# Altium schematic sheet RED_CIRCUITRY_1.SchDoc(RED_CIRCUITRY_1)
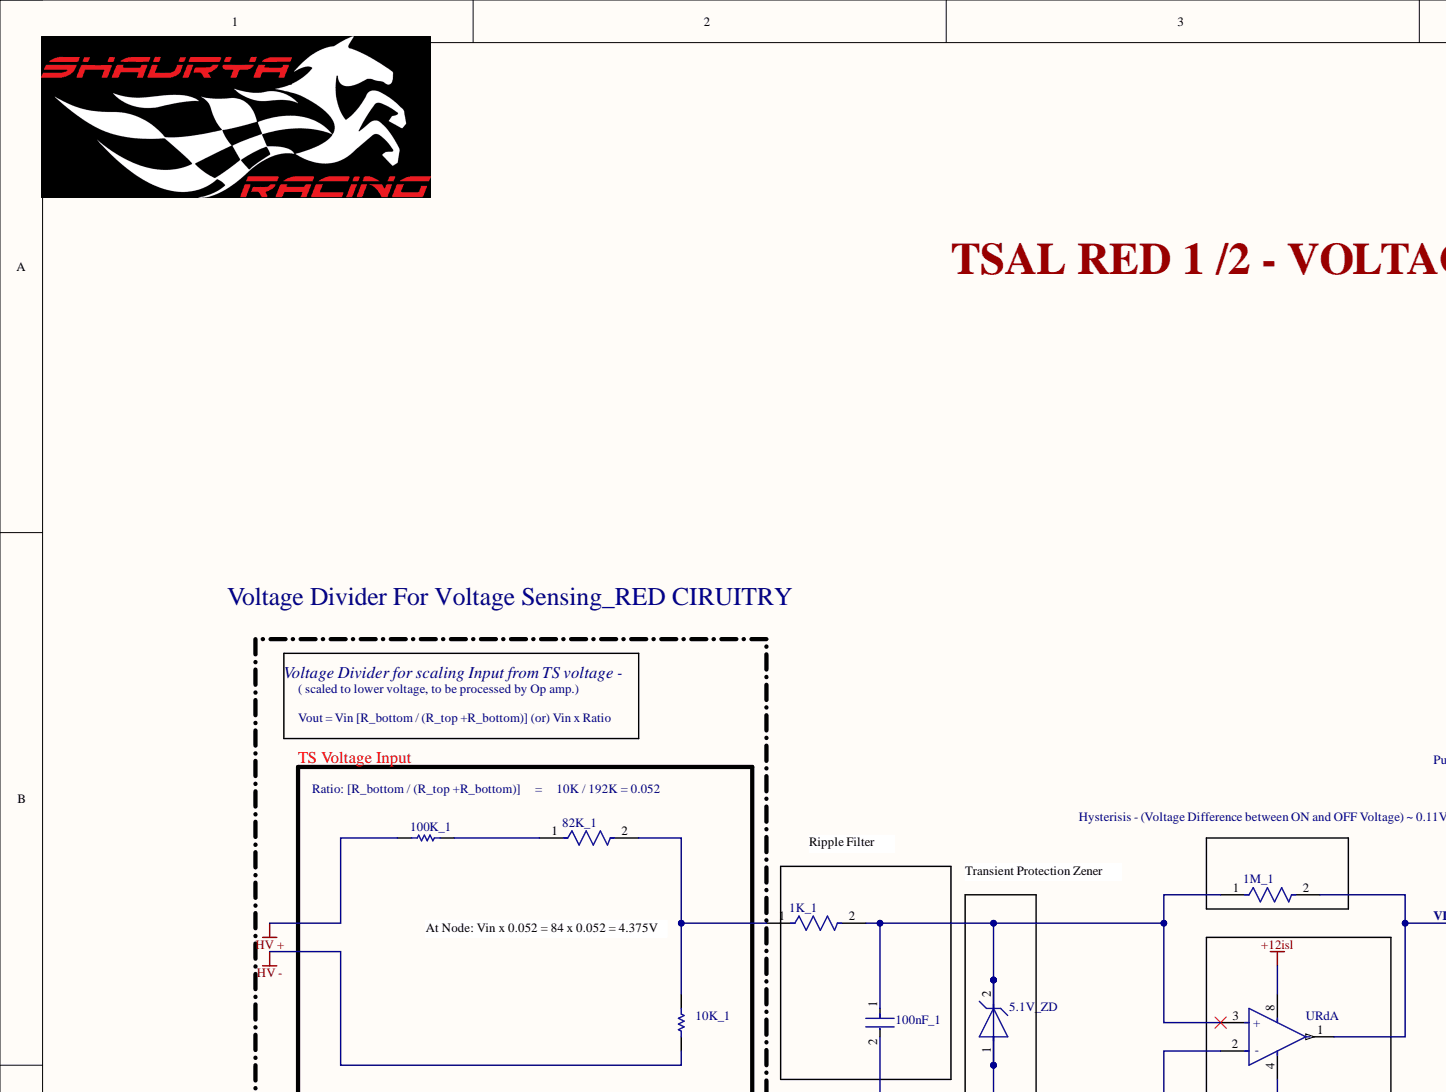
[diagram p0: RED_CIRCUITRY_1.SchDoc, top left]
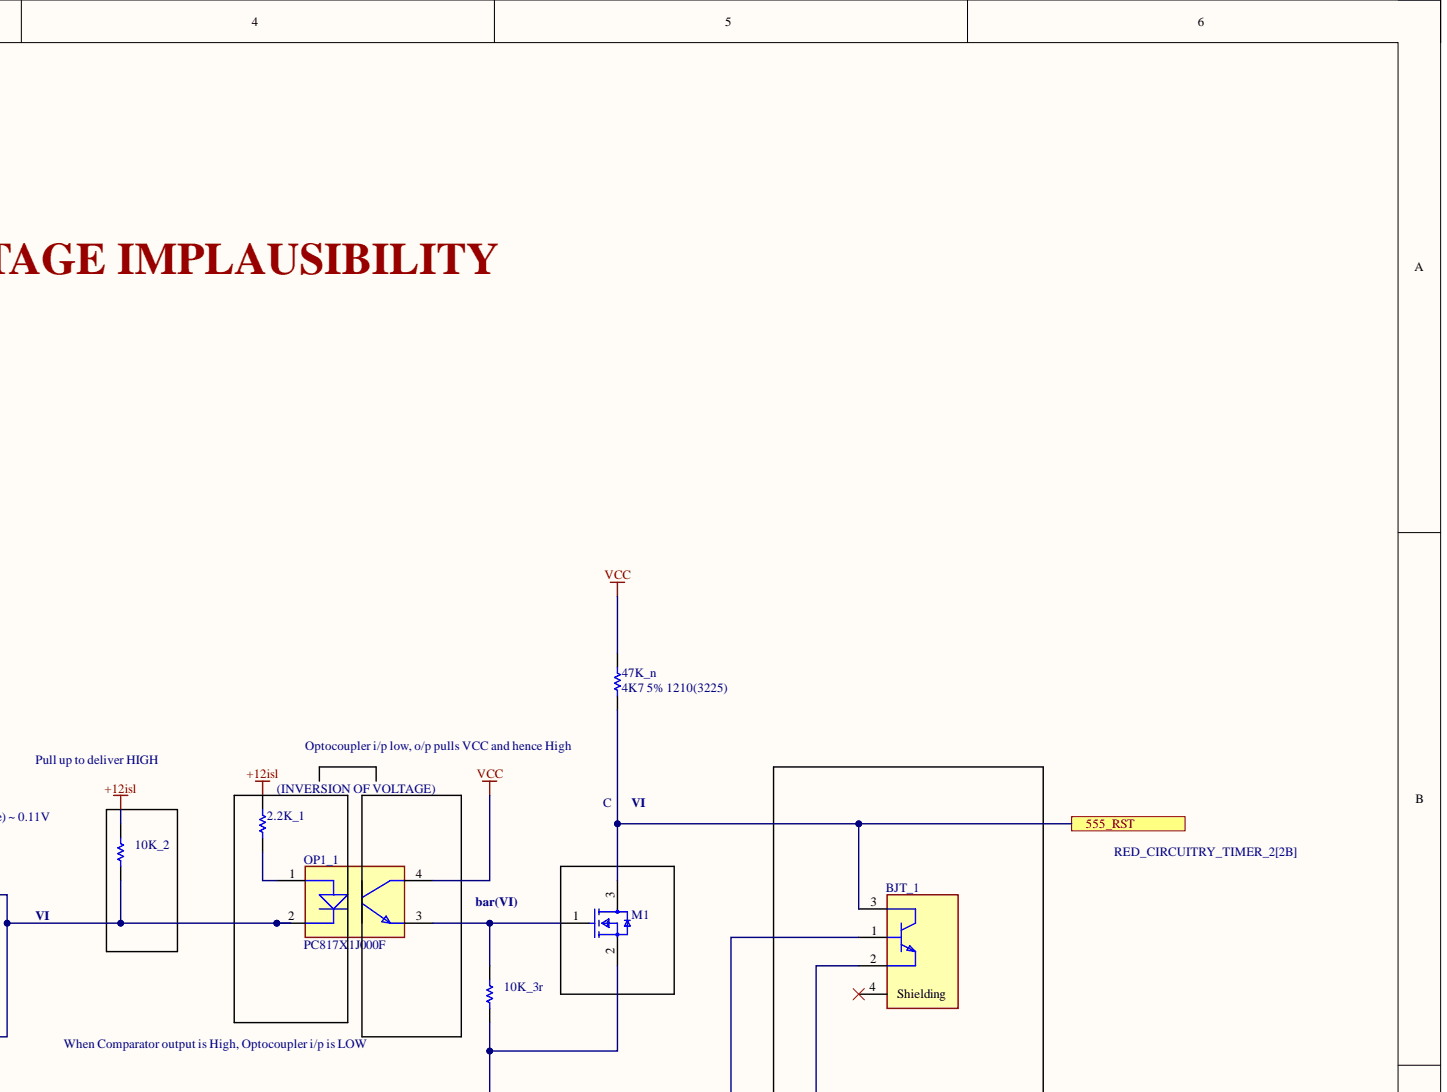
[diagram p1: RED_CIRCUITRY_1.SchDoc, top right]
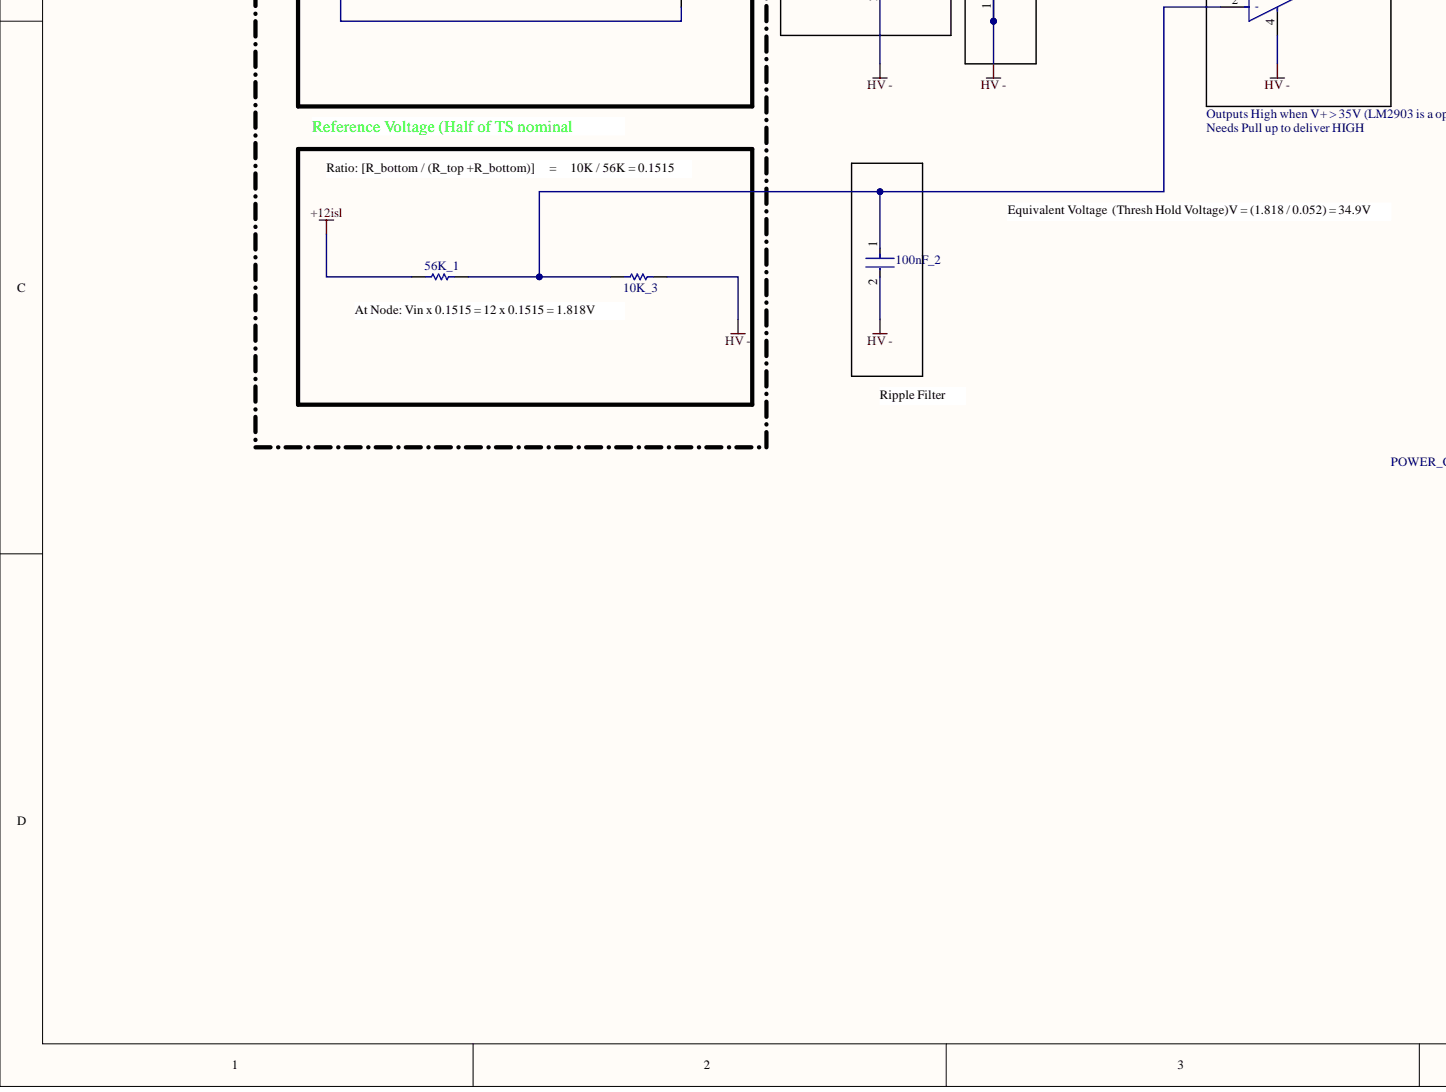
[diagram p2: RED_CIRCUITRY_1.SchDoc, bottom left]
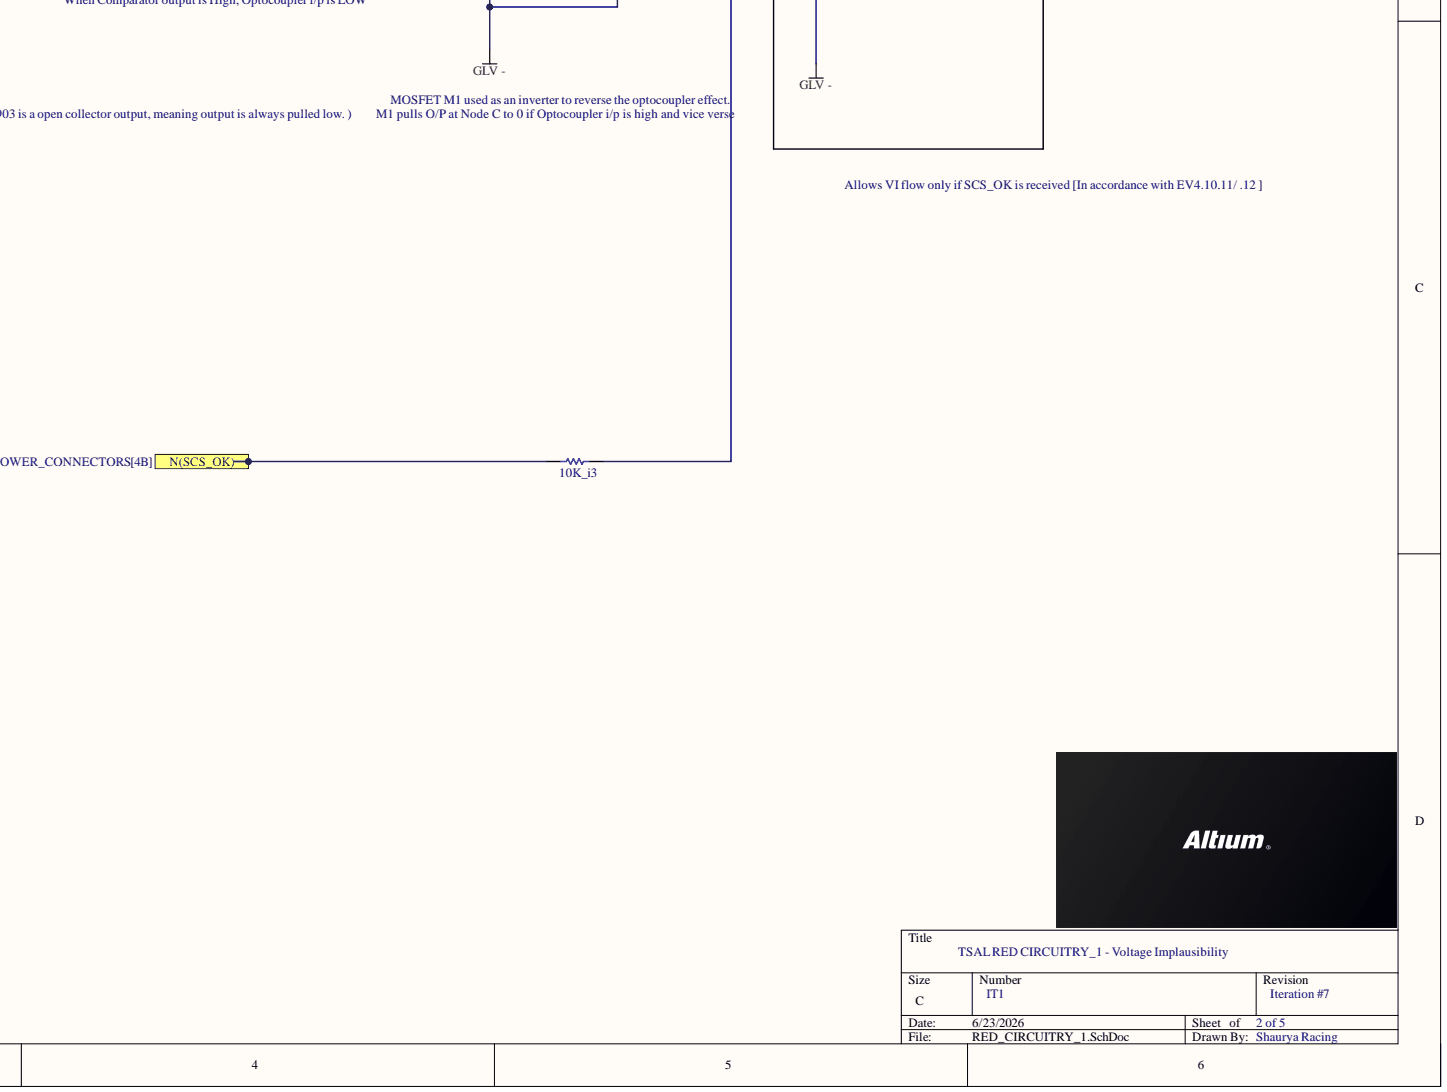
[diagram p3: RED_CIRCUITRY_1.SchDoc, bottom right]

## Parts
1K_1  CRCW08051K00JNEC  (value not drawn)
1M_1  MCU08050D1004BP500  (value not drawn)
2.2K_1  2K2 5% 0402(1005)  (value not drawn)
5.1V_ZD  BZD27C5V1P-E3-08  (value not drawn)
10K_1  TNPW121010K0BEEN  (value not drawn)
10K_2  TNPW121010K0BEEN  (value not drawn)
10K_3  TNPW121010K0BEEN  (value not drawn)
10K_3r  TNPW121010K0BEEN  (value not drawn)
10K_i3  TNPW121010K0BEEN  (value not drawn)
47K_n  4K7 5% 1210(3225)
56K_1  56K 5% 0805(2012)  (value not drawn)
82K_1  CRCW121082K0FKEA  (value not drawn)
100K_1  TNPW0805100KDEEA  (value not drawn)
100nF_1  C0805C104K5RACTU  (value not drawn)
100nF_2  C0805C104K5RACTU  (value not drawn)
BJT_1  JANTX2N2222AUB/TR  (value not drawn)
M1  2N7002H6327XTSA2  (value not drawn)
OP1_1  PC817X1J000F
URd  LM2903BIDR  (value not drawn)  (part A)

## Ports
555_RST, N(SCS_OK)

## Nets
+12isl: 2.2K_1.2 10K_2.2 56K_1.1 URd.8
GLV -: 10K_3r.1 BJT_1.2 M1.2
HV +: 100K_1.1
HV -: 1K_1.2 1M_1.1 5.1V_ZD.1 5.1V_ZD.2 10K_1.1 10K_3.2 100nF_1.1 100nF_1.2 100nF_2.2 URd.3(+) URd.4
VCC: 47K_n.2 OP1_1.4
555_RST: 47K_n.1 BJT_1.3 M1.3 ; port 555_RST
N(SCS_OK): 10K_i3.2 ; port N(SCS_OK)
Net1K_1_1: 1K_1.1 10K_1.2 82K_1.2
Net1M_1_2: 1M_1.2 10K_2.1 OP1_1.2 URd.1
Net2.2K_1_1: 2.2K_1.1 OP1_1.1
Net10K_3_1: 10K_3.1 56K_1.2 100nF_2.1 URd.2(-)
Net10K_3r_2: 10K_3r.2 M1.1 OP1_1.3
Net10K_i3_1: 10K_i3.1 BJT_1.1
Net82K_1_1: 82K_1.1 100K_1.2

Not connected: BJT_1 4(Shielding)

## Library data of the parts
1K_1 = CMP-02407-005778-1: RES Thick Film, 1kΩ, 5%, 0.125W, 200ppm/°C, 0805; Max Power Dissipation: 125mW; Resistance: 1kR; Case/Package: 0805; Component Kind: Standard; Composition: Thick Film; Contact Plating: Tin; Features: Automotive AEC-Q200; Height: 0.02inch; Lead Free: Lead Free; Length: 0.079inch; Library Name: Altium Content Vault; Max Operating Temperature: 155°C; Min Operating Temperature: -55°C; Number of Terminations: 2; Package Quantity: 20000; Packaging: Tape and Reel; Radiation Hardening: No; Temperature Coefficient: 200ppm/°C; Tolerance: 5%; Width: 0.049inch
1M_1 = CMP-02407-001361-1: RES 1.05K OHM 0.5% 1/5W 0805; Resistance: 1MR; Tolerance: 0.1%; Case/Package: 0805; Component Kind: Standard; Height: 450um; Lead Free: Lead Free; Length: 2mm; Library Name: Altium Content Vault; Width: 1.25mm
2.2K_1 = CMP-1011-00082-1: 2K2 0.063W 5% 0402 (1005 Metric)  SMD; Case-EIA: 0402; Case-Metric: 1005; Value: 2200.00 Ohm; Component Kind: Standard; Library Name: Altium Content Vault; Power: 0.063 W; Technology: SMT; Tolerance: 5 %
5.1V_ZD = CMP-02541-000027-1: DIODE ZENER 5.1V 800MW DO219AB; Element Configuration: Single; ESD Protection: Yes; Forward Voltage: 1.2V; Impedance: 6R; Max Reverse Current: 5uA; Test Current: 100mA; Tolerance: 6%; Voltage Tolerance: 5%; Breakdown Voltage: 5.1V; Case/Package: DO-219AB; Component Kind: Standard; Contact Plating: Tin; Height: 1.08mm; Lead Free: Lead Free; Length: 2.9mm; Library Name: Altium Content Vault; Max Operating Temperature: 175°C; Max Power Dissipation: 800mW; Max Reverse Leakage Current: 5uA; Min Operating Temperature: -65°C; Mount: Surface Mount; Number of Pins: 2; Package Quantity: 3000; Packaging: Tape and Reel; RoHS Compliant: Yes; Series: Automotive, AEC-Q101, BZD27C; Width: 1.9mm; Zener Current: 5uA; Zener Voltage: 5.1V
10K_1-10K_3 10K_3r 10K_i3 = CMP-2005-00857-1: Manufacturer: Vishay Dale; Component Kind: Standard; Library Name: Altium Content Vault; Part Number: TNPW121010K0BEEN
47K_n = CMP-1015-00090-1: 4K7 0.5W 5% 1210 (3225 Metric)  SMD; Case-EIA: 1210; Case-Metric: 3225; Value: 4700.00 Ohm; Component Kind: Standard; Library Name: Altium Content Vault; Power: 0.5 W; Technology: SMT; Tolerance: 5 %
56K_1 = CMP-1013-00116-1: 56K 0.125W 5% 0805 (2012 Metric)  SMD; Case-EIA: 0805; Case-Metric: 2012; Value: 56000.00 Ohm; Component Kind: Standard; Library Name: Altium Content Vault; Power: 0.125 W; Technology: SMT; Tolerance: 5 %
82K_1 = CMP-1015-00726-2: RES SMD 205K OHM 1% 1/2W 2203; Resistance: 82kR; Temperature Coefficient: 100ppm/°C; Voltage Rating (DC): 200V; Case/Package: 1210; Component Kind: Standard; Composition: Thick Film; Contact Plating: Tin; Height: 550um; Lead Free: Lead Free; Length: 3.2mm; Library Name: Altium Content Vault; Max Operating Temperature: 155°C; Min Operating Temperature: -55°C; Packaging: Tape and Reel; Radiation Hardening: No; Tolerance: 1%; Width: 2.5mm
100K_1 = CMP-2001-04708-1: Manufacturer: Vishay Dale; Component Kind: Standard; Library Name: Altium Content Vault; Part Number: TNPW0805100KDEEA
100nF_1 100nF_2 = CMP-2007-00279-2: CAP CER 0.1UF 50V X7R 0805; Manufacturer: KEMET; Capacitance: 0.10 μF; Temperature Coefficient: 30ppm/°C; Tolerance: 0.1; Value: 0.10 μF; Automotive: No; Category: Cap; Component Kind: Standard; ComponentLink2Description: Datasheet; ComponentLink2URL: https://content.kemet.com/datasheets/KEM_C1003_C0G_SMD.pdf; Device Class L1: Passive Components; Device Class L2: Capacitors; Device Class L3: Ceramic Capacitors; Digikey Description: CAP CER 0.1UF 50V X7R 0805; Height: 0.88mm; Ipc Land Pattern Name: CAPC200125X78; Lead Free: Yes; Library Name: Altium Content Vault; Manufacturer Part Number: C0805C104K5RACTU; Material: Ceramic; Mouser Description: Cap Ceramic 0.1uF 50V X7R 10% SMD 2.0 x 1.2 mm 125 C Paper T/R; Octopart: https://octopart.com/c0805c104k5ractu-kemet-143926; Package: C0805C-DN; RoHS: TRUE; Temperature: -55°C to +125°C; Temperature Characteristic: X7R; Temperature Range High: +125°C; Temperature Range Low: -55°C; Voltage Rating: 50 V
BJT_1 = CMP-00252-101463705-1: JANTX2N2222AUB/TR Trans Gp Bjt NPN 50V 0.8A 3-PIN Ub T/r; Collector Base Voltage (VCBO): 75V; Collector Emitter Saturation Voltage: 300mV; Emitter Base Voltage (VEBO): 6V; hFE Min: 100; Max Collector Current: 800mA; Max Junction Temperature (Tj): 200°C; Max Power Dissipation: 500mW; Number of Elements: 1; Collector Emitter Breakdown Voltage: 50V; Collector Emitter Voltage (VCEO): 50V; Component Kind: Standard; Height: 1.8mm; Library Name: Altium Content Vault; Max Operating Temperature: 200°C; Min Operating Temperature: -65°C; Mount: Surface Mount; NexarPartId: 101463705; Number of Pins: 3; Polarity: NPN; Power Dissipation: 500mW; Radiation Hardening: No; RoHS: Non-Compliant; Schedule B: 8541290040
M1 = CMP-32901-000180-1: MOSFET N-CH 60V 0.3A SOT23; Continuous Drain Current (ID): 300mA; Gate to Source Voltage (Vgs): 20V; Input Capacitance: 20pF; Max Power Dissipation: 500mW; Rds On Max: 3R; Rise Time: 3.3ns; Case/Package: SOT-23; Component Kind: Standard; Drain to Source Voltage (Vdss): 60V; Halogen Free: Halogen Free; Lead Free: Lead Free; Library Name: Altium Content Vault; Max Dual Supply Voltage: 60V; Max Operating Temperature: 150°C; Min Operating Temperature: -55°C; Mount: Surface Mount; Number of Pins: 3; Packaging: Tape and Reel
OP1_1 = CMP-65434-000003-1: OPTOISOLATOR 5KV TRANS 4DIP; Collector Emitter Breakdown Voltage: 80V; Collector Emitter Saturation Voltage: 200mV; Current Transfer Ratio: 600%; Fall Time: 18000ns; Forward Current: 50mA; Forward Voltage: 1.4V; Input Current: 20mA; Max Power Dissipation: 200mW; Number of Channels: 1; Reverse Breakdown Voltage: 6V; Case/Package: DIP; Collector Emitter Voltage (VCEO): 80V; Component Kind: Standard; Isolation Voltage: 5kV; Library Name: Altium Content Vault; Max Collector Current: 50mA; Max Input Current: 50mA; Max Operating Temperature: 100°C; Min Operating Temperature: -30°C; Mount: Through Hole; Number of Elements: 1; Number of Pins: 4; Output Current per Channel: 50mA; Output Voltage: 80V; Package Quantity: 2000; Packaging: Sleeve; Power Dissipation: 200mW; Radiation Hardening: No; Rise Time: 18000ns
URd = CMP-04896-000007-1: 36V JI.3 DUAL COMPARATOR; Manufacturer: Texas Instruments; Input Bias Current: -0.0035uA; Input Offset Voltage: 4mV; Max Junction Temp: +150°C; Min Supply Voltage: 3V; Nominal Supply Current: 400-550uA; Number Of Channels: 2; Output Current Per Channel: 25mA; Response Time: 1us; Standoff Height: 0.11mm; Ambient Temperature Range High: +125°C; Ambient Temperature Range Low: -40°C; Automotive: No; Category: IC; Component Kind: Standard; ComponentLink2Description: Datasheet; ComponentLink2URL: http://www.ti.com/lit/ds/symlink/lm2903b.pdf; Device Class L1: Integrated Circuits (ICs); Device Class L2: Linear ICs; Device Class L3: Comparators; Digikey Description: 36V JI.3 DUAL COMPARATOR; Height: 1.75mm; Lead Free: Yes; Library Name: Altium Content Vault; Manufacturer Part Number: LM2903BIDR; Max Supply Voltage: 36V; Octopart: https://octopart.com/lm2903bidr-texas+instruments-104977165; Package: D0008A; RoHS: TRUE; Temperature: -40°C to +125°C; Temperature Range High: +125°C; Temperature Range Low: -40°C
